# Altium schematic sheet [09] - POWER.SchDoc
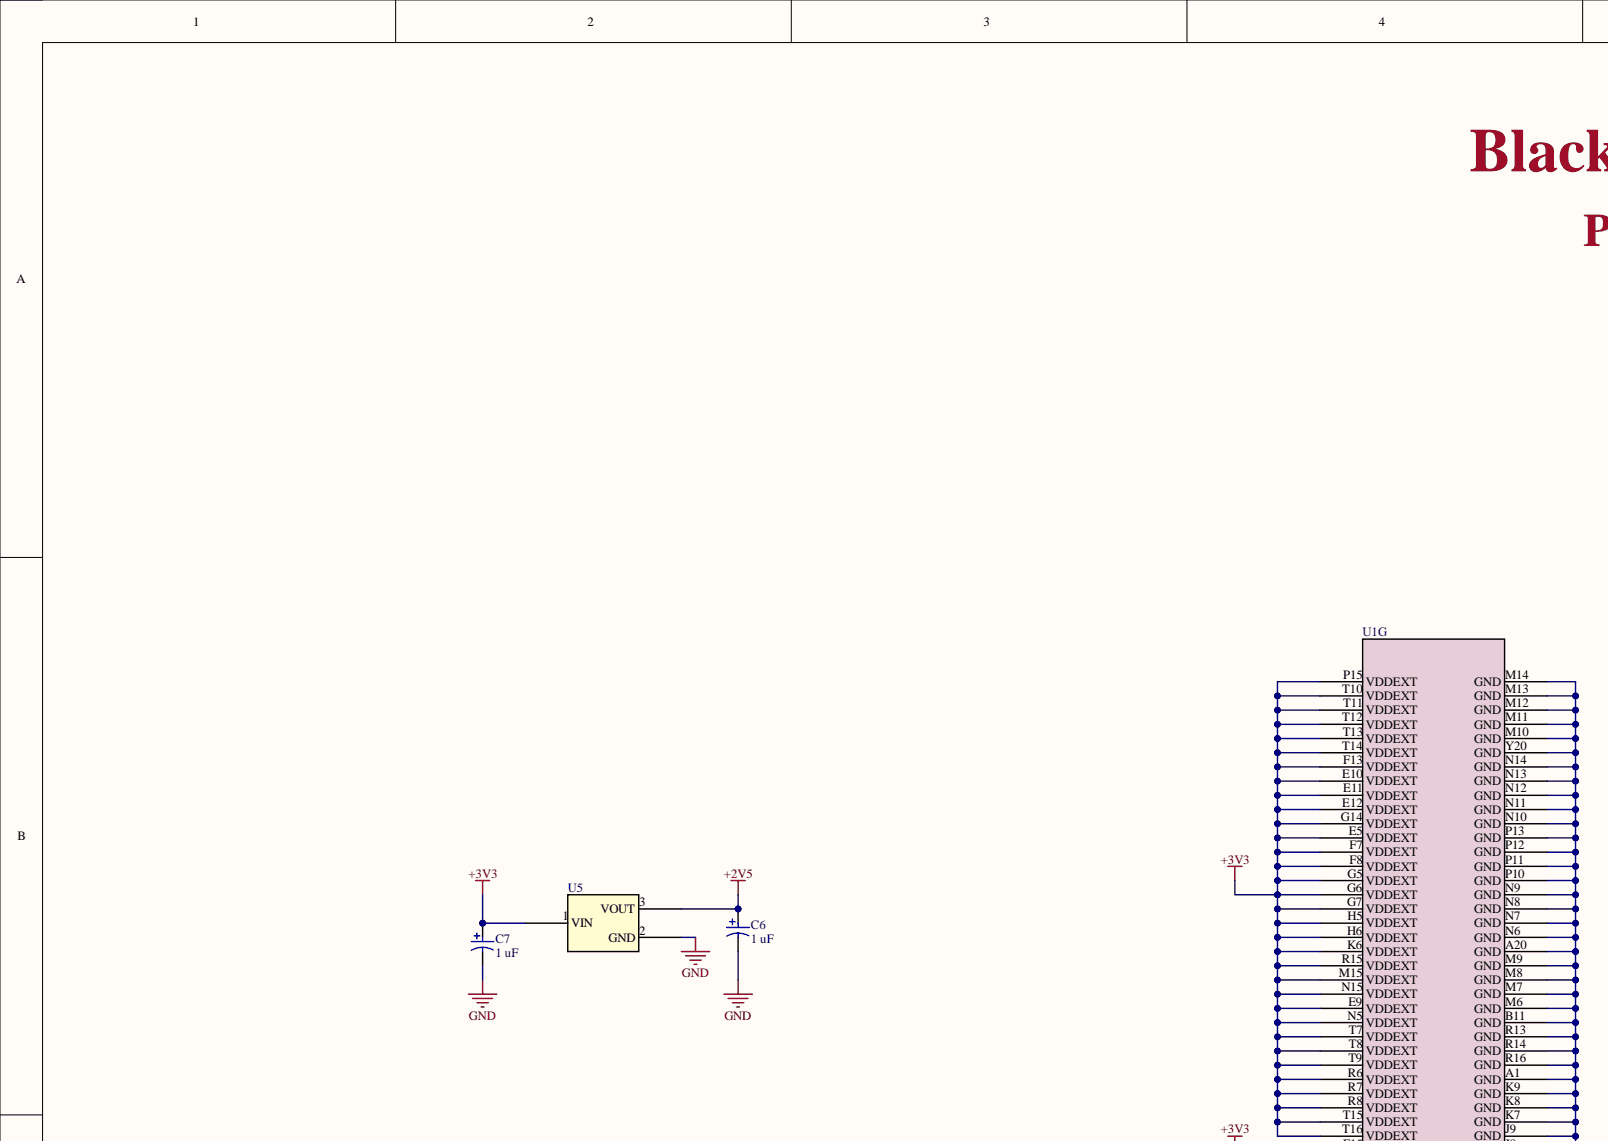
[diagram p0: [09] - POWER.SchDoc, top left]
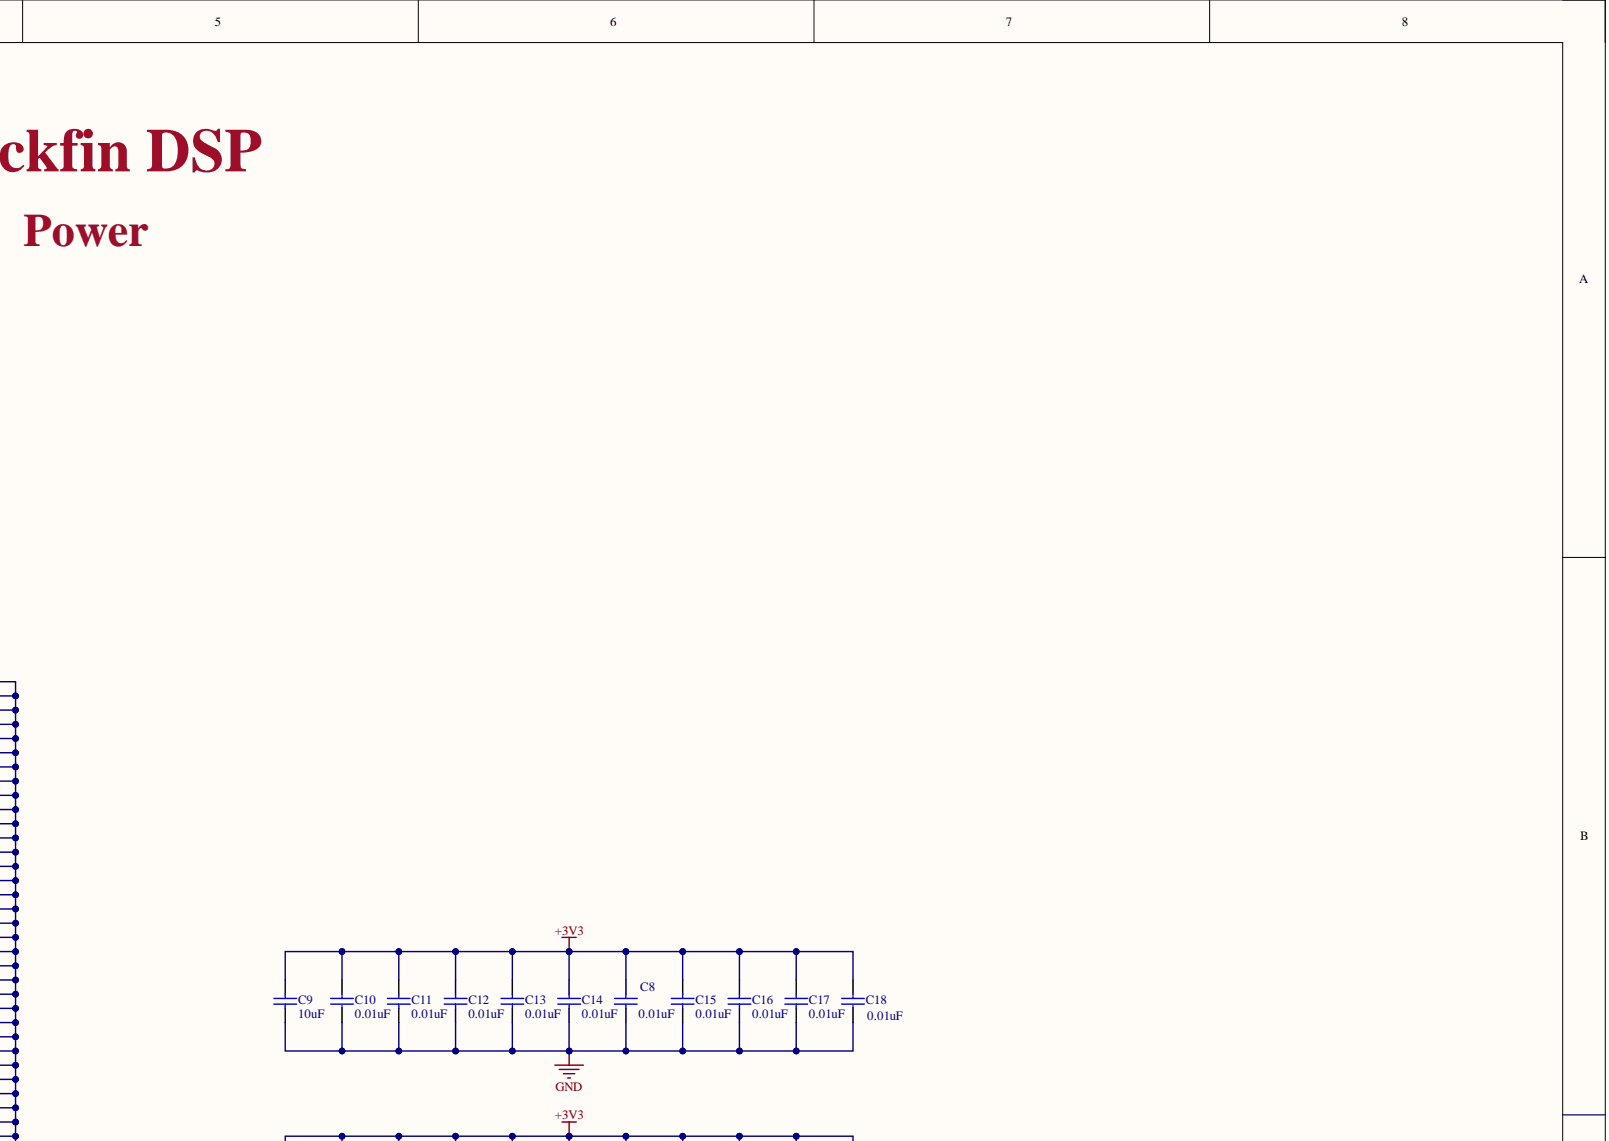
[diagram p1: [09] - POWER.SchDoc, top right]
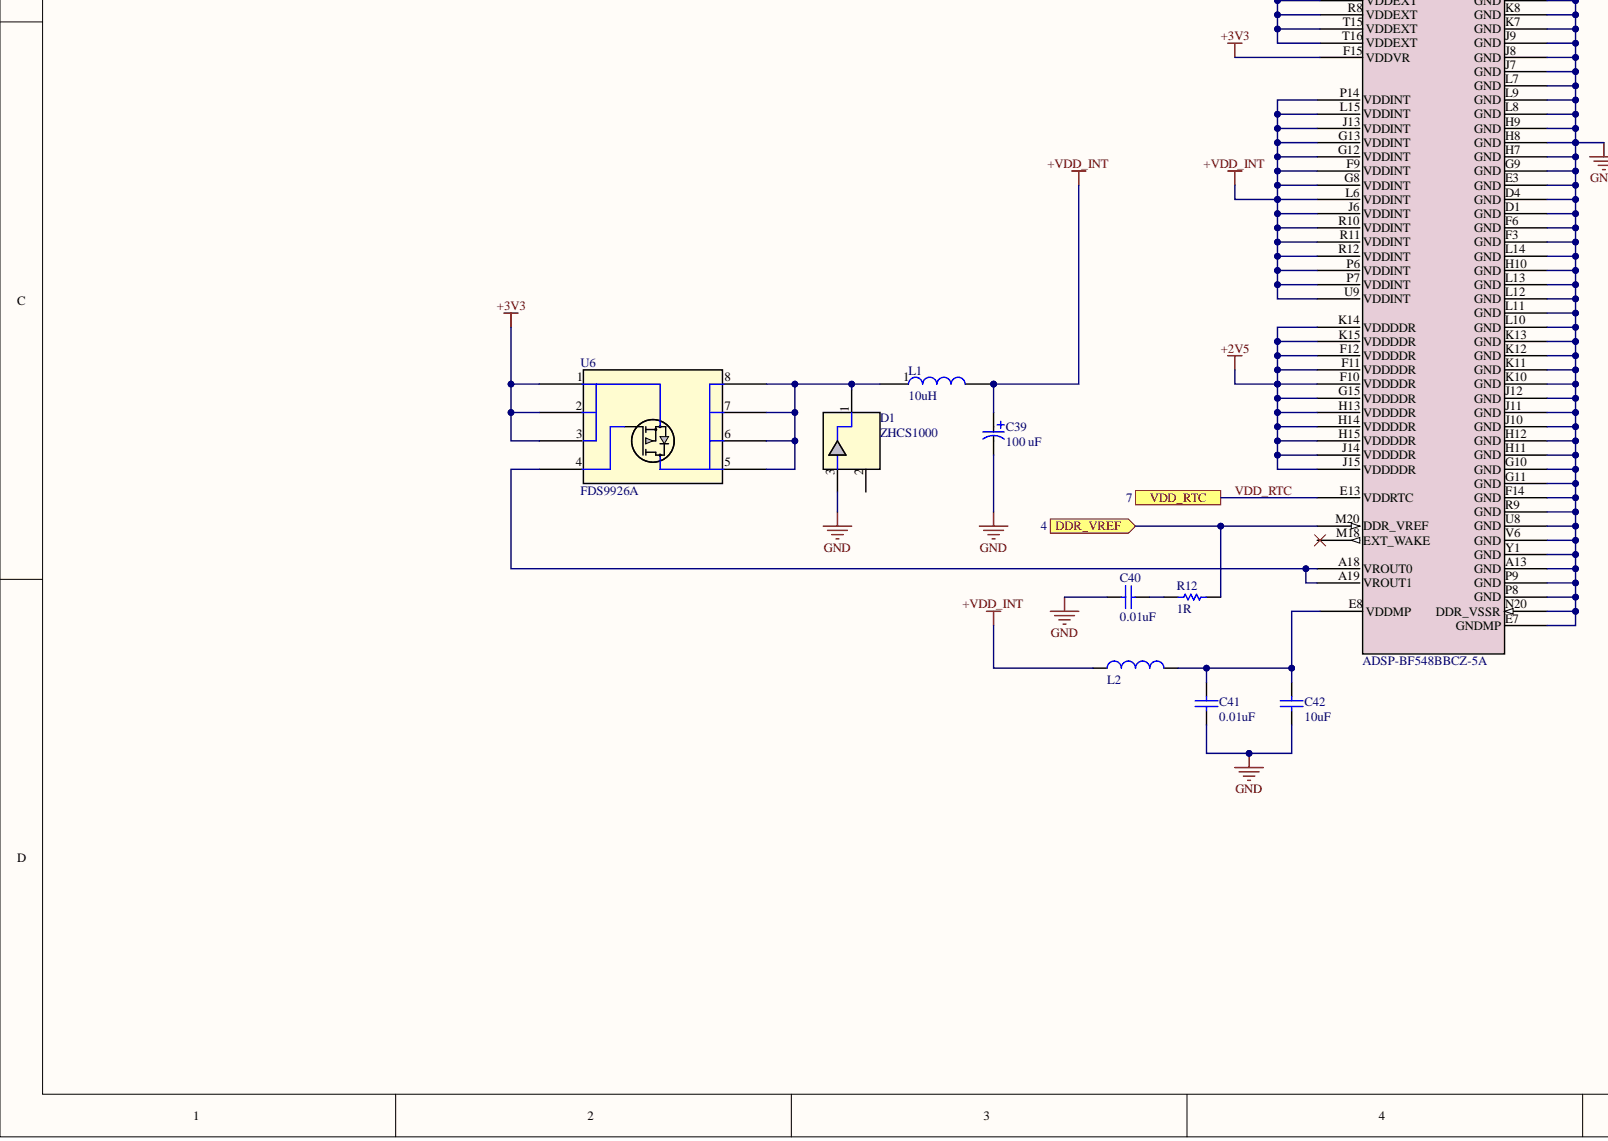
[diagram p2: [09] - POWER.SchDoc, bottom left]
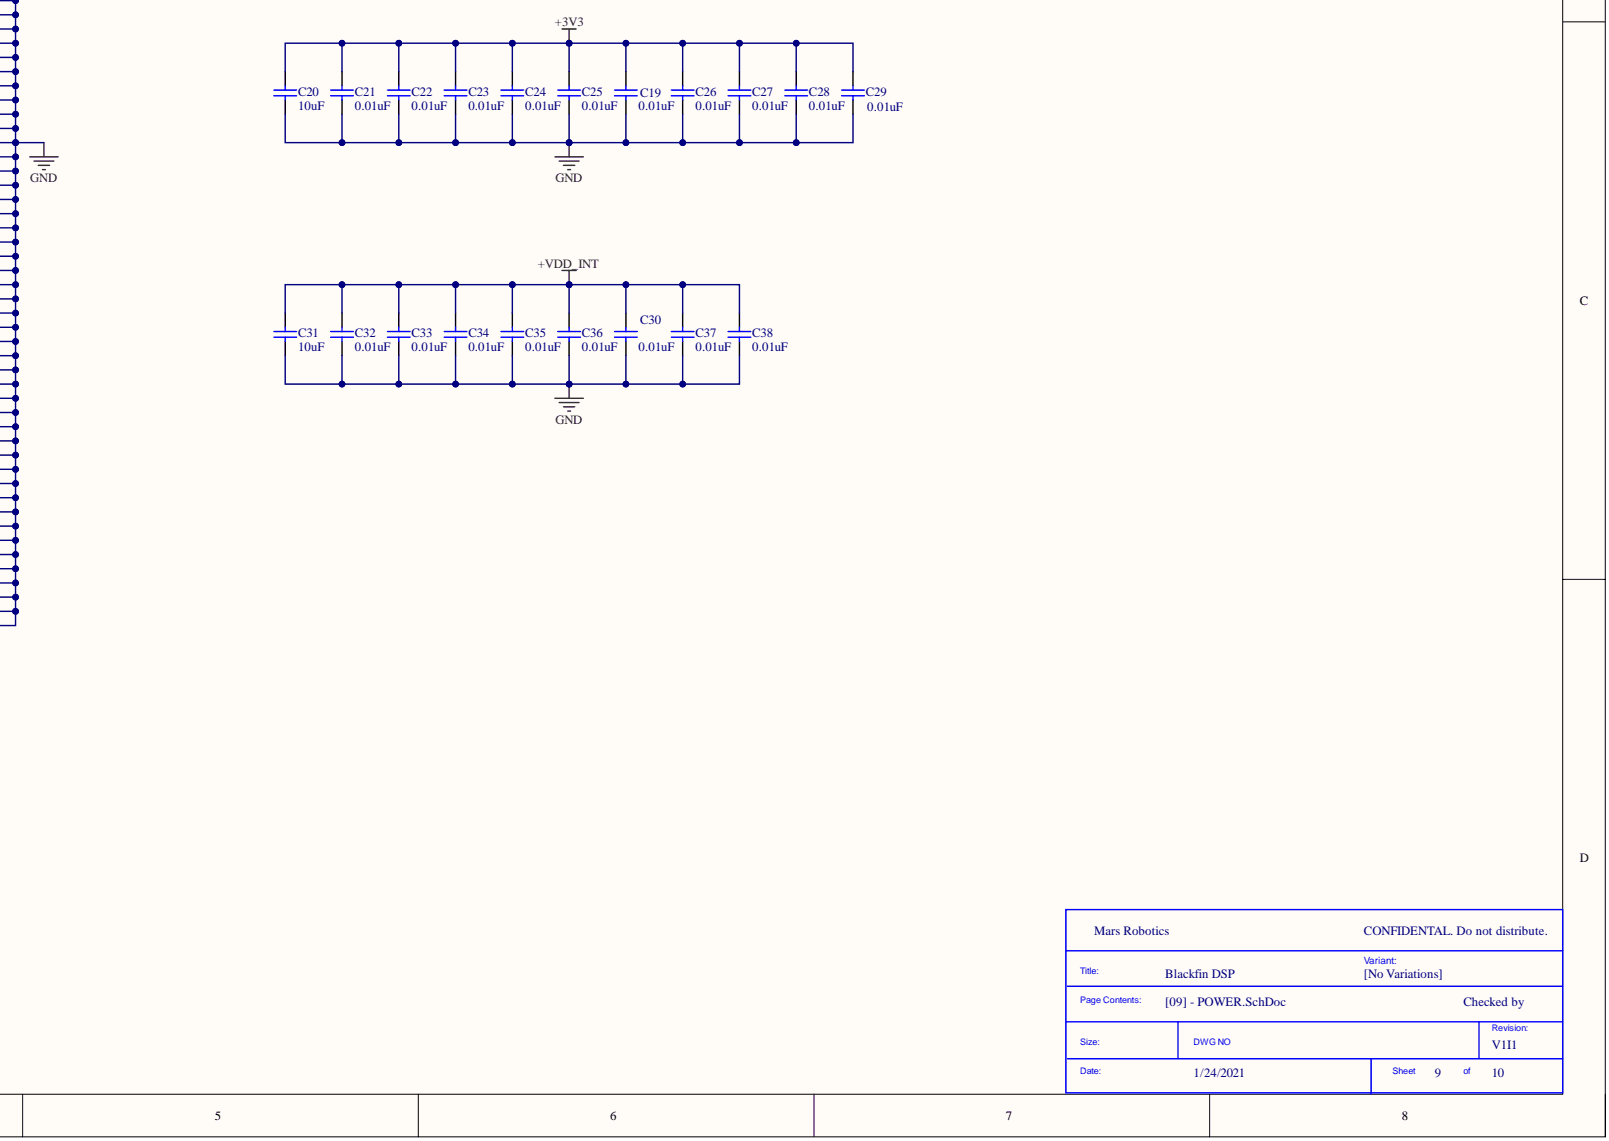
[diagram p3: [09] - POWER.SchDoc, bottom right]

## Parts
C6  1 uF  [CAP_TANT_B]
C7  1 uF  [CAP_TANT_B]
C8  0.01uF  [CAP0402]
C9  10uF  [CAP0805]
C10  0.01uF  [CAP0402]
C11  0.01uF  [CAP0402]
C12  0.01uF  [CAP0402]
C13  0.01uF  [CAP0402]
C14  0.01uF  [CAP0402]
C15  0.01uF  [CAP0402]
C16  0.01uF  [CAP0402]
C17  0.01uF  [CAP0402]
C18  0.01uF  [CAP0402]
C19  0.01uF  [CAP0402]
C20  10uF  [CAP0805]
C21  0.01uF  [CAP0402]
C22  0.01uF  [CAP0402]
C23  0.01uF  [CAP0402]
C24  0.01uF  [CAP0402]
C25  0.01uF  [CAP0402]
C26  0.01uF  [CAP0402]
C27  0.01uF  [CAP0402]
C28  0.01uF  [CAP0402]
C29  0.01uF  [CAP0402]
C30  0.01uF  [CAP0402]
C31  10uF  [CAP0805]
C32  0.01uF  [CAP0402]
C33  0.01uF  [CAP0402]
C34  0.01uF  [CAP0402]
C35  0.01uF  [CAP0402]
C36  0.01uF  [CAP0402]
C37  0.01uF  [CAP0402]
C38  0.01uF  [CAP0402]
C39  100 uF  [CAP_TANT_C]
C40  0.01uF  [CAP0402]
C41  0.01uF  [CAP0402]
C42  10uF  [CAP0805]
D1  ZHCS1000  [SOT23_3_TOS-L]
L1  10uH  [IND_5818_WE-TPC]
L2  600 Ohms @ 100MHz  [IND-0603]  (value not drawn)
R12  1R  [RES0402]
U1  ADSP-BF548BBCZ-5A  [BC_400_1_ADI]  (part G)
U5  TC1262-2.5VDBTR  [SOT-223]  (value not drawn)
U6  FDS9926A  [SOIC8]

## Ports
DDR_VREF, VDD_RTC

## Nets
+2V5: C6.1 U1.F10(VDDDDR) U1.F11(VDDDDR) U1.F12(VDDDDR) U1.G15(VDDDDR) U1.H13(VDDDDR) U1.H14(VDDDDR) U1.H15(VDDDDR) U1.J14(VDDDDR) U1.J15(VDDDDR) U1.K14(VDDDDR) U1.K15(VDDDDR) U5.3
+3V3: C7.1 C8.2 C9.2 C10.2 C11.2 C12.2 C13.2 C14.2 C15.2 C16.2 C17.2 C18.2 C19.2 C20.2 C21.2 C22.2 C23.2 C24.2 C25.2 C26.2 C27.2 C28.2 C29.2 U1.E5(VDDEXT) U1.E9(VDDEXT) U1.E10(VDDEXT) U1.E11(VDDEXT) U1.E12(VDDEXT) U1.F7(VDDEXT) U1.F8(VDDEXT) U1.F13(VDDEXT) U1.F15(VDDVR) U1.G5(VDDEXT) U1.G6(VDDEXT) U1.G7(VDDEXT) U1.G14(VDDEXT) U1.H5(VDDEXT) U1.H6(VDDEXT) U1.K6(VDDEXT) U1.M15(VDDEXT) U1.N5(VDDEXT) U1.N15(VDDEXT) U1.P15(VDDEXT) U1.R6(VDDEXT) U1.R7(VDDEXT) U1.R8(VDDEXT) U1.R15(VDDEXT) U1.T7(VDDEXT) U1.T8(VDDEXT) U1.T9(VDDEXT) U1.T10(VDDEXT) U1.T11(VDDEXT) U1.T12(VDDEXT) U1.T13(VDDEXT) U1.T14(VDDEXT) U1.T15(VDDEXT) U1.T16(VDDEXT) U5.1 U6.1 U6.2 U6.3
+VDD_INT: C30.2 C31.2 C32.2 C33.2 C34.2 C35.2 C36.2 C37.2 C38.2 C39.1 L1.2 L2.1 U1.F9(VDDINT) U1.G8(VDDINT) U1.G12(VDDINT) U1.G13(VDDINT) U1.J6(VDDINT) U1.J13(VDDINT) U1.L6(VDDINT) U1.L15(VDDINT) U1.P6(VDDINT) U1.P7(VDDINT) U1.P14(VDDINT) U1.R10(VDDINT) U1.R11(VDDINT) U1.R12(VDDINT) U1.U9(VDDINT)
GND: C6.2 C7.2 C8.1 C9.1 C10.1 C11.1 C12.1 C13.1 C14.1 C15.1 C16.1 C17.1 C18.1 C19.1 C20.1 C21.1 C22.1 C23.1 C24.1 C25.1 C26.1 C27.1 C28.1 C29.1 C30.1 C31.1 C32.1 C33.1 C34.1 C35.1 C36.1 C37.1 C38.1 C39.2 C40.1 C41.1 C42.1 D1.3 U1.A1(GND) U1.A13(GND) U1.A20(GND) U1.B11(GND) U1.D1(GND) U1.D4(GND) U1.E3(GND) U1.E7(GNDMP) U1.F3(GND) U1.F6(GND) U1.F14(GND) U1.G9(GND) U1.G10(GND) U1.G11(GND) U1.H7(GND) U1.H8(GND) U1.H9(GND) U1.H10(GND) U1.H11(GND) U1.H12(GND) U1.J7(GND) U1.J8(GND) U1.J9(GND) U1.J10(GND) U1.J11(GND) U1.J12(GND) U1.K7(GND) U1.K8(GND) U1.K9(GND) U1.K10(GND) U1.K11(GND) U1.K12(GND) U1.K13(GND) U1.L7(GND) U1.L8(GND) U1.L9(GND) U1.L10(GND) U1.L11(GND) U1.L12(GND) U1.L13(GND) U1.L14(GND) U1.M6(GND) U1.M7(GND) U1.M8(GND) U1.M9(GND) U1.M10(GND) U1.M11(GND) U1.M12(GND) U1.M13(GND) U1.M14(GND) U1.N6(GND) U1.N7(GND) U1.N8(GND) U1.N9(GND) U1.N10(GND) U1.N11(GND) U1.N12(GND) U1.N13(GND) U1.N14(GND) U1.N20(DDR_VSSR) U1.P8(GND) U1.P9(GND) U1.P10(GND) U1.P11(GND) U1.P12(GND) U1.P13(GND) U1.R9(GND) U1.R13(GND) U1.R14(GND) U1.R16(GND) U1.U8(GND) U1.V6(GND) U1.Y1(GND) U1.Y20(GND) U5.2
DDR_VREF: R12.2 U1.M20(DDR_VREF) ; port DDR_VREF
VDD_RTC: U1.E13(VDDRTC) ; port VDD_RTC
NetC40_2: C40.2 R12.1
NetC41_2: C41.2 C42.2 L2.2 U1.E8(VDDMP)
NetD1_1: D1.1 L1.1 U6.5 U6.6 U6.7 U6.8
NetU1_A18: U1.A18(VROUT0) U1.A19(VROUT1) U6.4

Not connected: D1 2; U1 M18(EXT_WAKE)

## Library data of the parts
C6 C7 = T491B105M025AT: Tantalum Capacitor, 1 uF, +/- 10%, 25 V, -55 to 125 degC, 1411 (3528 Metric), RoHS, Tape and Reel; Manufacturer: Kemet; Capacitance: 1uF; Case Style: Molded; DCL: 0.5uA; DF: 4%; ESR: 5ohm; Insulation: NotRequired; Lead Spacing (nom): NotRequiredmm; Polarity: Polar; Product Depth (mm): 2.8mm; Product Height (mm): 1.9mm; Product Length (mm): 3.5mm; Voltage: 25VDC; Case Code: B; Component Kind: Standard; Construction: SMTChip; Datasheet URL: http://capacitoredge.kemet.com/capedge2/DataSheet/Datasheet-T491B105K025AT.pdf?pn=T491B105K025AT; Datasheet Version: 10/2016; Failure Rate: NotRequired; Length W/Weld: NotRequiredmm; Manufacturer URL: http://www.kemet.com/; Mounting Style: SurfaceMount; Mounting Technology: SurfaceMount; Operating Temp Range: -55Cto125C; Package / Case: 3528-21; Package Description: 2-Pin Surface Mount Device, Body 3.5 x 2.8 mm; Package Reference: T491-B; Packaging: TapeandReel; Product Diameter (mm): NotRequiredmm; Seal: NotRequired; Seated Plane Height: NotRequiredmm; Tolerance (+ or -): 10%; Wire Form: NotRequired; Library Name: BlackfinSOM.SchLib
C8 C10-C19 C21-C30 C32-C38 C40 C41 = CMP-2008-02797-1: AVX - 0402YC103KAT2A - SMD Multilayer Ceramic Capacitor, 0,01 uF, 16 V, 0402 [1005 Metric], ± 10%, X7R, AVX 0402 MLCC; Manufacturer: AVX Interconnect / Elco; Capacitance: 10nF; Insulation Resistance: 100GR; Voltage: 16V; Case Code (Imperial): 0402; Case Code (Metric): 1005; Case/Package: 0402; Category: Ceramic Capacitors; Component Kind: Standard; ComponentLink1Description: https://datasheet.ciiva.com/11788/0900766b80dc2409-11788541.pdf?src-supplier=RSComponents; ComponentLink1URL: https://datasheet.ciiva.com/11788/0900766b80dc2409-11788541.pdf?src-supplier=RSComponents; Contact Plating: Tin,Matte; Depth: 0.5mm; Dielectric: X7R; Height: 0.5mm; Lead Free: Lead Free; Length: 1mm; Manufacturer Part Number: 0402YC103KAT2A; Max Operating Temperature: 125°C; Min Operating Temperature: -55°C; Mount: Surface Mount; Package Quantity: 1; Packaging: Tape and Reel; Part Number: 0402YC103KAT2A; Pricing 1: 1=0.10000, 10=0.02100, 50=0.01120, 100=0.00920, 500=0.00654, 1000=0.00514, 2500=0.00468, 5000=0.00430 (USD); Radiation Hardening: No; REACH SVHC: No SVHC; RoHS: Yes; Stock 1: 1668180; Supplier 1: Digi-Key; Termination: SMD/SMT; Thickness: 0.022inch; Tolerance: 10%; Voltage Rating: 16V; Voltage Rating (DC): 16V; Width: 0.5mm; Library Name: BlackfinSOM.SchLib
C9 C20 C31 C42 = 08056D106KAT2A: Cap Ceramic 10uF 6.3V X5R 10% SMD 0805 85C Embossed T/R; Manufacturer: AVX Interconnect / Elco; Insulation Resistance: 500MR; Capacitance: 10uF; Case Code (Imperial): 0805; Case Code (Metric): 2012; Case/Package: 0805; Category: Ceramic Capacitors; Component Kind: Standard; ComponentLink1Description: https://datasheet.ciiva.com/11788/0900766b80def1a5-11788549.pdf?src-supplier=RSComponents; ComponentLink1URL: https://datasheet.ciiva.com/11788/0900766b80def1a5-11788549.pdf?src-supplier=RSComponents; Depth: 1.25mm; Dielectric: X5R; Dielectric Material: Ceramic; Height: 1.4mm; Lead Free: Lead Free; Length: 2.01mm; Manufacturer Part Number: 08056D106KAT2A; Max Operating Temperature: 85°C; Min Operating Temperature: -55°C; Mount: Surface Mount,PCB; Number of Pins: 2; Package Quantity: 1; Packaging: Tape and Reel; Part Number: 08056D106KAT2A; Pricing 1: 1=0.24000, 10=0.16300, 50=0.09800, 100=0.08280, 500=0.05662, 1000=0.05009 (USD); Radiation Hardening: No; REACH SVHC: No SVHC; RoHS: Yes; Stock 1: 132568; Supplier 1: Digi-Key; Termination: SMD/SMT; Thickness: 0.055inch; Tolerance: 10%; Voltage: 6.3V; Voltage Rating: 6.3V; Voltage Rating (DC): 6.3V; Width: 1.25mm; Library Name: BlackfinSOM.SchLib
C39 = T495C107K006ATE075: CAP TANT 100UF 6.3V 10% 2312; Manufacturer: KEMET; Built_By: EMA_Frank; Dissipation Factor: 8%; ESR (Equivalent Series Resistance): 75mR; Leakage Current: 6.3uA; Life (Hours): 2000Hour; Polarity: Polar; Resistance: 0.075R; Ripple Current: 1.09A; Source_Library: Kemet_2020-03-25_3.xlr; SourceLibrary: Kemet_2020-03-25_3; Type: DEV; Capacitance: 100uF; Case Code (Imperial): 2312; Case Code (Metric): 6032; Case/Package: Molded; Category: Tantalum Capacitors; Component Kind: Standard; ComponentLink1Description: https://datasheet.ciiva.com/23810/1744558-23810593.pdf?src-supplier=Newark; ComponentLink1URL: https://datasheet.ciiva.com/23810/1744558-23810593.pdf?src-supplier=Newark; ComponentLink2Description: https://datasheet.ciiva.com/22691/1761160-22691450.pdf?src-supplier=Element14; ComponentLink2URL: https://datasheet.ciiva.com/22691/1761160-22691450.pdf?src-supplier=Element14; ComponentLink3Description: https://datasheet.ciiva.com/22691/1744558-22691451.pdf?src-supplier=Element14; ComponentLink3URL: https://datasheet.ciiva.com/22691/1744558-22691451.pdf?src-supplier=Element14; Depth: 3.2mm; Features: General Purpose; Height: 2.8mm; Height - Seated (Max): 0.11inch; Length: 6mm; Manufacturer Part Number: T495C107K006ATE075; Manufacturer_Part_Number: T495C107K006ATE075; Max Operating Temperature: 125°C; Min Operating Temperature: -55°C; Mount: Surface Mount; Package Quantity: 1; Packaging: Tape and Reel; Pricing 1: 1=1.19000, 10=0.93800, 50=0.81260, 100=0.70310 (USD); Radiation Hardening: No; REACH SVHC: No SVHC; RefDes: RefDes; RoHS: Yes; Stock 1: 5300; Supplier 1: Digi-Key; Termination: SMD/SMT; Tolerance: 10%; Vendor: Kemet; Voltage Rating: 6.3V; Voltage Rating (DC): 6.3V; Width: 0.126inch; Copyright: Copyright (C) 2018 Accelerated Designs. All rights reserved; Library Name: BlackfinSOM.SchLib
D1 = ZHCS1000: DIODES INC. - ZHCS1000 - Schottky Rectifier, 40 V, 1 A, Single, SOT-23, 3 Pins, 425 mV; Forward Current: 1A; Forward Voltage: 425mV; Max Forward Surge Current (Ifsm): 12A; Max Power Dissipation: 500mW; Max Repetitive Reverse Voltage (Vrrm): 40V; Reverse Recovery Time: 12ns; Case/Package: SOT-23; Category: Rectifier Diodes; Component Kind: Standard; ComponentLink1Description: https://datasheet.ciiva.com/6932/1643161-6932065.pdf?src-supplier=Newark; ComponentLink1URL: https://datasheet.ciiva.com/6932/1643161-6932065.pdf?src-supplier=Newark; ComponentLink2Description: https://datasheet.ciiva.com/7964/312100-7964339.pdf?src-supplier=Element14; ComponentLink2URL: https://datasheet.ciiva.com/7964/312100-7964339.pdf?src-supplier=Element14; Element Configuration: Single; Manufacturer: Diodes; Manufacturer Part Number: ZHCS1000; Max Operating Temperature: 125°C; Number of Pins: 3; Packaging: Cut Tape; REACH SVHC: No SVHC; RoHS: Yes; Supplier 1: Newark; Termination: SMD/SMT; Width: 3.05mm; Library Name: BlackfinSOM.SchLib
L1 = 744053100: 10µH Shielded Wirewound Inductor 1.5A 70mOhm Max 2323 (5858 Metric); Manufacturer: Würth Elektronik; Current Rating: 1,5A; Component Kind: Standard; Digi-Key Part Number: 732-1043-2-ND; Inductance: 10µH; Manufacturer Product Number: 744053100; Package / Case: 2323 (5858 Metric); Library Name: BlackfinSOM.SchLib
L2 = 732-2389-2-ND: Ferritperle, 0603 [Metrisch 1608], 600 ohm, 500 mA, WE-CBF HF Series, 0.35 ohm, ± 25%; Manufacturer: Wurth Electronics; Manufacturer Part Number: 742863160; Core Material: Ferrite; DC Resistance (DCR): 350mR; Impedance: 600 Ohm; Max Current Rating: 500mA; Number of Lines: 1; Case Code (Imperial): 0603; Case Code (Metric): 1608; Case/Package: SMD/SMT; Category: Ferrite Beads and Chips; Component Kind: Standard; ComponentLink1Description: Manufacturer Link; ComponentLink1URL: http://www.we-online.com; ComponentLink2Description: Datasheet; ComponentLink2URL: http://www.we-online.de/katalog/media/pdf/742863160.pdf; ComponentLink3Description: https://datasheet.ciiva.com/4939/742863160-51474-4939408.pdf?src-supplier=Mouser; ComponentLink3URL: https://datasheet.ciiva.com/4939/742863160-51474-4939408.pdf?src-supplier=Mouser; ComponentLink4Description: https://datasheet.ciiva.com/23763/742863160-23763056.pdf?src-supplier=Newark; ComponentLink4URL: https://datasheet.ciiva.com/23763/742863160-23763056.pdf?src-supplier=Newark; ComponentLink5Description: https://datasheet.ciiva.com/30490/0900766b812a6726-30490032.pdf?src-supplier=RSComponents; ComponentLink5URL: https://datasheet.ciiva.com/30490/0900766b812a6726-30490032.pdf?src-supplier=RSComponents; ComponentLink6Description: https://datasheet.ciiva.com/6212/742863160-6212664.pdf?src-supplier=Digi-Key; ComponentLink6URL: https://datasheet.ciiva.com/6212/742863160-6212664.pdf?src-supplier=Digi-Key; ComponentLink7Description: https://datasheet.ciiva.com/1466/1696149-1466636.pdf?src-supplier=Element14; ComponentLink7URL: https://datasheet.ciiva.com/1466/1696149-1466636.pdf?src-supplier=Element14; ComponentLink8Description: https://datasheet.ciiva.com/6212/742863160-6212666.pdf?src-supplier=Digi-Key; ComponentLink8URL: https://datasheet.ciiva.com/6212/742863160-6212666.pdf?src-supplier=Digi-Key; ComponentLink9Description: https://datasheet.ciiva.com/6212/742863160-6212667.pdf?src-supplier=Digi-Key; ComponentLink9URL: https://datasheet.ciiva.com/6212/742863160-6212667.pdf?src-supplier=Digi-Key; ComponentLink10Description: https://datasheet.ciiva.com/22711/1696149-22711452.pdf?src-supplier=Element14; ComponentLink10URL: https://datasheet.ciiva.com/22711/1696149-22711452.pdf?src-supplier=Element14; DatasheetVersion: ver 2, Aug-2009; Depth: 0.8mm; Height: 0.8mm; Lead Free: Lead Free; Length: 1.6mm; Max DC Current: 500mA; Max Operating Temperature: 125°C; Min Operating Temperature: -55°C; Mount: Surface Mount; Number of Pins: 2; Package Quantity: 1; PackageDescription: Chip Inductor, 2-Leads, Body 1.6 x 0.8 mm; PackageReference: SMD-0603; PackageVersion: 2009; Packaging: Tape and Reel; Pricing 1: 1=0.22000, 50=0.21200, 100=0.20200, 500=0.18100, 1000=0.17100, 2000=0.16100 (USD); REACH SVHC: Unknown; Resistance: 350mR; RoHS: Yes; Stock 1: 26940; Supplier 1: Digi-Key; Termination: SMD/SMT; Test Frequency: 100MHz; Tolerance: 25%; Width: 0.8mm; Library Name: BlackfinSOM.SchLib
R12 = 541-1.00LCT-ND: RES SMD 1 OHM 1% 1/16W 0402; Manufacturer: Vishay; Manufacturer Part Number: CRCW04021R00FNED; Max Power Dissipation: 63mW; Temperature Coefficient: 200ppm/°C; Voltage Rating: 50V; Case Code (Imperial): 0402; Case Code (Metric): 1005; Case/Package: 0402; Category: Chip SMD Resistors; Component Kind: Standard; ComponentLink1Description: https://datasheet.ciiva.com/2560/dcrcwe3-2560101.pdf?src-supplier=Digi-Key; ComponentLink1URL: https://datasheet.ciiva.com/2560/dcrcwe3-2560101.pdf?src-supplier=Digi-Key; ComponentLink2Description: https://datasheet.ciiva.com/6027/1697337-6027861.pdf?src-supplier=Farnell; ComponentLink2URL: https://datasheet.ciiva.com/6027/1697337-6027861.pdf?src-supplier=Farnell; ComponentLink3Description: https://datasheet.ciiva.com/2560/dcrcwe3-2560102.pdf?src-supplier=Digi-Key; ComponentLink3URL: https://datasheet.ciiva.com/2560/dcrcwe3-2560102.pdf?src-supplier=Digi-Key; ComponentLink4Description: https://datasheet.ciiva.com/2560/dcrcwe3-2560103.pdf?src-supplier=Digi-Key; ComponentLink4URL: https://datasheet.ciiva.com/2560/dcrcwe3-2560103.pdf?src-supplier=Digi-Key; ComponentLink5Description: https://datasheet.ciiva.com/3256/dcrcwe3-3256199.pdf?src-supplier=Avnet; ComponentLink5URL: https://datasheet.ciiva.com/3256/dcrcwe3-3256199.pdf?src-supplier=Avnet; ComponentLink6Description: https://datasheet.ciiva.com/3256/dcrcwe3-3256200.pdf?src-supplier=Avnet; ComponentLink6URL: https://datasheet.ciiva.com/3256/dcrcwe3-3256200.pdf?src-supplier=Avnet; ComponentLink7Description: https://datasheet.ciiva.com/5552/dcrcwe3-109170-5552232.pdf?src-supplier=Mouser; ComponentLink7URL: https://datasheet.ciiva.com/5552/dcrcwe3-109170-5552232.pdf?src-supplier=Mouser; ComponentLink8Description: https://datasheet.ciiva.com/16436/dcrcwe3-16436173.pdf?src-supplier=Future+Electronics; ComponentLink8URL: https://datasheet.ciiva.com/16436/dcrcwe3-16436173.pdf?src-supplier=Future+Electronics; ComponentLink9Description: https://datasheet.ciiva.com/7841/125364-7841807.pdf?src-supplier=Element14; ComponentLink9URL: https://datasheet.ciiva.com/7841/125364-7841807.pdf?src-supplier=Element14; Contact Plating: Tin; Depth: 0.5mm; Features: Automotive AEC-Q200; Height: 0.016inch; Lead Free: Lead Free; Length: 1mm; Max Operating Temperature: 155°C; Military Standard: Not; Min Operating Temperature: -55°C; Mount: Surface Mount; Number of Pins: 2; Number of Terminations: 2; Package Quantity: 10000; Packaging: Tape and Reel; Power Rating: 63mW; Pricing 1: 1=0.10000, 10=0.05000, 100=0.02040, 1000=0.00914, 2500=0.00794, 5000=0.00656 (USD); Radiation Hardening: No; REACH SVHC: Unknown; Resistance: 1R; Resistor Type: Thick Film; RoHS: Yes; Stock 1: 7772; Supplier 1: Digi-Key; Termination: SMD/SMT; Tolerance: 1%; Voltage Rating (DC): 50V; Weight: 2.3E-05oz; Width: 0.02inch; Library Name: BlackfinSOM.SchLib
U1 = ADSP-BF548BBCZ-5A: IC DSP 16BIT 533MHZ 400CSBGA; Manufacturer: Analog Devices Inc.; Component Kind: Standard; Manufacturer Product Number: ADSP-BF548BBCZ-5A; Manufacturer_Part_Number: ADSP-BF548BBCZ-5A; Supplier: Analog Devices Inc.; TypeDigi-Key Part Number: ADSP-BF548BBCZ-5A-ND; Library Name: BlackfinSOM.SchLib
U5 = TC1262-2.5VDBTR: Linear Voltage Regulator IC  1 Output  500mA SOT-223-3; Manufacturer: Microchip; Accuracy: 0.5%; Dropout Voltage: 350mV; Input Bias Current: 80uA; Max Input Voltage: 6V; Min Input Voltage: 2.7V; Number of Outputs: 1; Output Type: Fixed; Output Voltage: 2.5V; Polarity: Positive; Case/Package: SOT-223-3; Category: Voltage Regulators - Linear; Component Kind: Standard; ComponentLink1Description: https://datasheet.ciiva.com/2056/filehandler-aspxddocname-en026002-2056324.pdf?src-supplier=Digi-Key; ComponentLink1URL: https://datasheet.ciiva.com/2056/filehandler-aspxddocname-en026002-2056324.pdf?src-supplier=Digi-Key; ComponentLink2Description: https://datasheet.ciiva.com/2056/filehandler-aspxddocname-en026002-2056325.pdf?src-supplier=Digi-Key; ComponentLink2URL: https://datasheet.ciiva.com/2056/filehandler-aspxddocname-en026002-2056325.pdf?src-supplier=Digi-Key; ComponentLink3Description: https://datasheet.ciiva.com/2056/filehandler-aspxddocname-en026002-2056326.pdf?src-supplier=Digi-Key; ComponentLink3URL: https://datasheet.ciiva.com/2056/filehandler-aspxddocname-en026002-2056326.pdf?src-supplier=Digi-Key; ComponentLink4Description: https://datasheet.ciiva.com/8239/21373b-8239238.pdf?src-supplier=Avnet; ComponentLink4URL: https://datasheet.ciiva.com/8239/21373b-8239238.pdf?src-supplier=Avnet; Contact Plating: Tin,Matte; Manufacturer Part Number: TC1262-2.5VDBTR; Max Operating Temperature: 125°C; Max Output Current: 500mA; Min Operating Temperature: -40°C; Mount: Surface Mount; Number of Pins: 3; Number of Regulators: 1; Output Current: 500mA; Package Quantity: 4000; Packaging: Tape and Reel; Pricing 1: 1=0.51000, 25=0.43000, 100=0.39000 (USD); Quiescent Current: 80uA; Radiation Hardening: No; RoHS: Yes; Stock 1: 2386; Supplier 1: Digi-Key; Library Name: BlackfinSOM.SchLib
U6 = FDS9926A: Trans MOSFET P-CH 20V 3.5A 8-Pin SOIC N T/R; Manufacturer: ON Semiconductor / Fairchild; Manufacturer Part Number: FDS9431A; Current Rating: -3.5A; Drain to Source Breakdown Voltage: -20V; Drain to Source Resistance: 130mR; Element Configuration: Single; Fall Time: 21ns; Gate to Source Voltage (Vgs): 8V; Input Capacitance: 405pF; Max Power Dissipation: 2.5W; Nominal Vgs: -600mV; Number of Elements: 1; Rise Time: 20ns; Turn-Off Delay Time: 31ns; Turn-On Delay Time: 6.5ns; Case/Package: SOIC; Category: MOSFETs; Component Kind: Standard; ComponentLink1Description: https://datasheet.ciiva.com/1256/fds9431a-1256128.pdf?src-supplier=Newark; ComponentLink1URL: https://datasheet.ciiva.com/1256/fds9431a-1256128.pdf?src-supplier=Newark; ComponentLink2Description: https://datasheet.ciiva.com/15137/getdatasheetpartid-70130-15137336.pdf?src-supplier=Verical; ComponentLink2URL: https://datasheet.ciiva.com/15137/getdatasheetpartid-70130-15137336.pdf?src-supplier=Verical; ComponentLink3Description: https://datasheet.ciiva.com/4441/fds9431a-111226-4441596.pdf?src-supplier=Mouser; ComponentLink3URL: https://datasheet.ciiva.com/4441/fds9431a-111226-4441596.pdf?src-supplier=Mouser; ComponentLink4Description: https://datasheet.ciiva.com/932/fds9431a-932295.pdf?src-supplier=Digi-Key; ComponentLink4URL: https://datasheet.ciiva.com/932/fds9431a-932295.pdf?src-supplier=Digi-Key; ComponentLink5Description: https://datasheet.ciiva.com/932/fds9431a-932296.pdf?src-supplier=Digi-Key; ComponentLink5URL: https://datasheet.ciiva.com/932/fds9431a-932296.pdf?src-supplier=Digi-Key; ComponentLink6Description: https://datasheet.ciiva.com/8114/fds9431a-8114667.pdf?src-supplier=Avnet; ComponentLink6URL: https://datasheet.ciiva.com/8114/fds9431a-8114667.pdf?src-supplier=Avnet; ComponentLink7Description: https://datasheet.ciiva.com/932/fds9431a-932294.pdf?src-supplier=Digi-Key; ComponentLink7URL: https://datasheet.ciiva.com/932/fds9431a-932294.pdf?src-supplier=Digi-Key; ComponentLink8Description: https://datasheet.ciiva.com/26141/fds9431a-26141927.pdf?src-supplier=Avnet+Europe; ComponentLink8URL: https://datasheet.ciiva.com/26141/fds9431a-26141927.pdf?src-supplier=Avnet+Europe; ComponentLink9Description: https://datasheet.ciiva.com/8114/fds9431a-8114671.pdf?src-supplier=Avnet; ComponentLink9URL: https://datasheet.ciiva.com/8114/fds9431a-8114671.pdf?src-supplier=Avnet; Contact Plating: Tin,Matte; Continuous Drain Current (ID): 3.5A; Drain to Source Voltage (Vdss): 20V; Dual Supply Voltage: -20V; Height: 1.5mm; Lead Free: Lead Free; Length: 5mm; Max Operating Temperature: 175°C; Min Operating Temperature: -55°C; Mount: Surface Mount; Number of Pins: 8; On-State Resistance: 130mR; Package Quantity: 2500; Packaging: Tape and Reel; Power Dissipation: 2.5W; Pricing 1: 1=0.68000, 10=0.59800, 25=0.56160, 100=0.40750, 500=0.34046, 1000=0.28976 (USD); Radiation Hardening: No; Rds On Max: 130mR; REACH SVHC: No SVHC; RoHS: Yes; Stock 1: 2709; Supplier 1: Digi-Key; Termination: SMD/SMT; Threshold Voltage: -600mV; Voltage Rating (DC): -20V; Weight: 0.2304g; Width: 4mm; Library Name: BlackfinSOM.SchLib
